# Altium schematic sheet toplevel.SchDoc(toplevel)
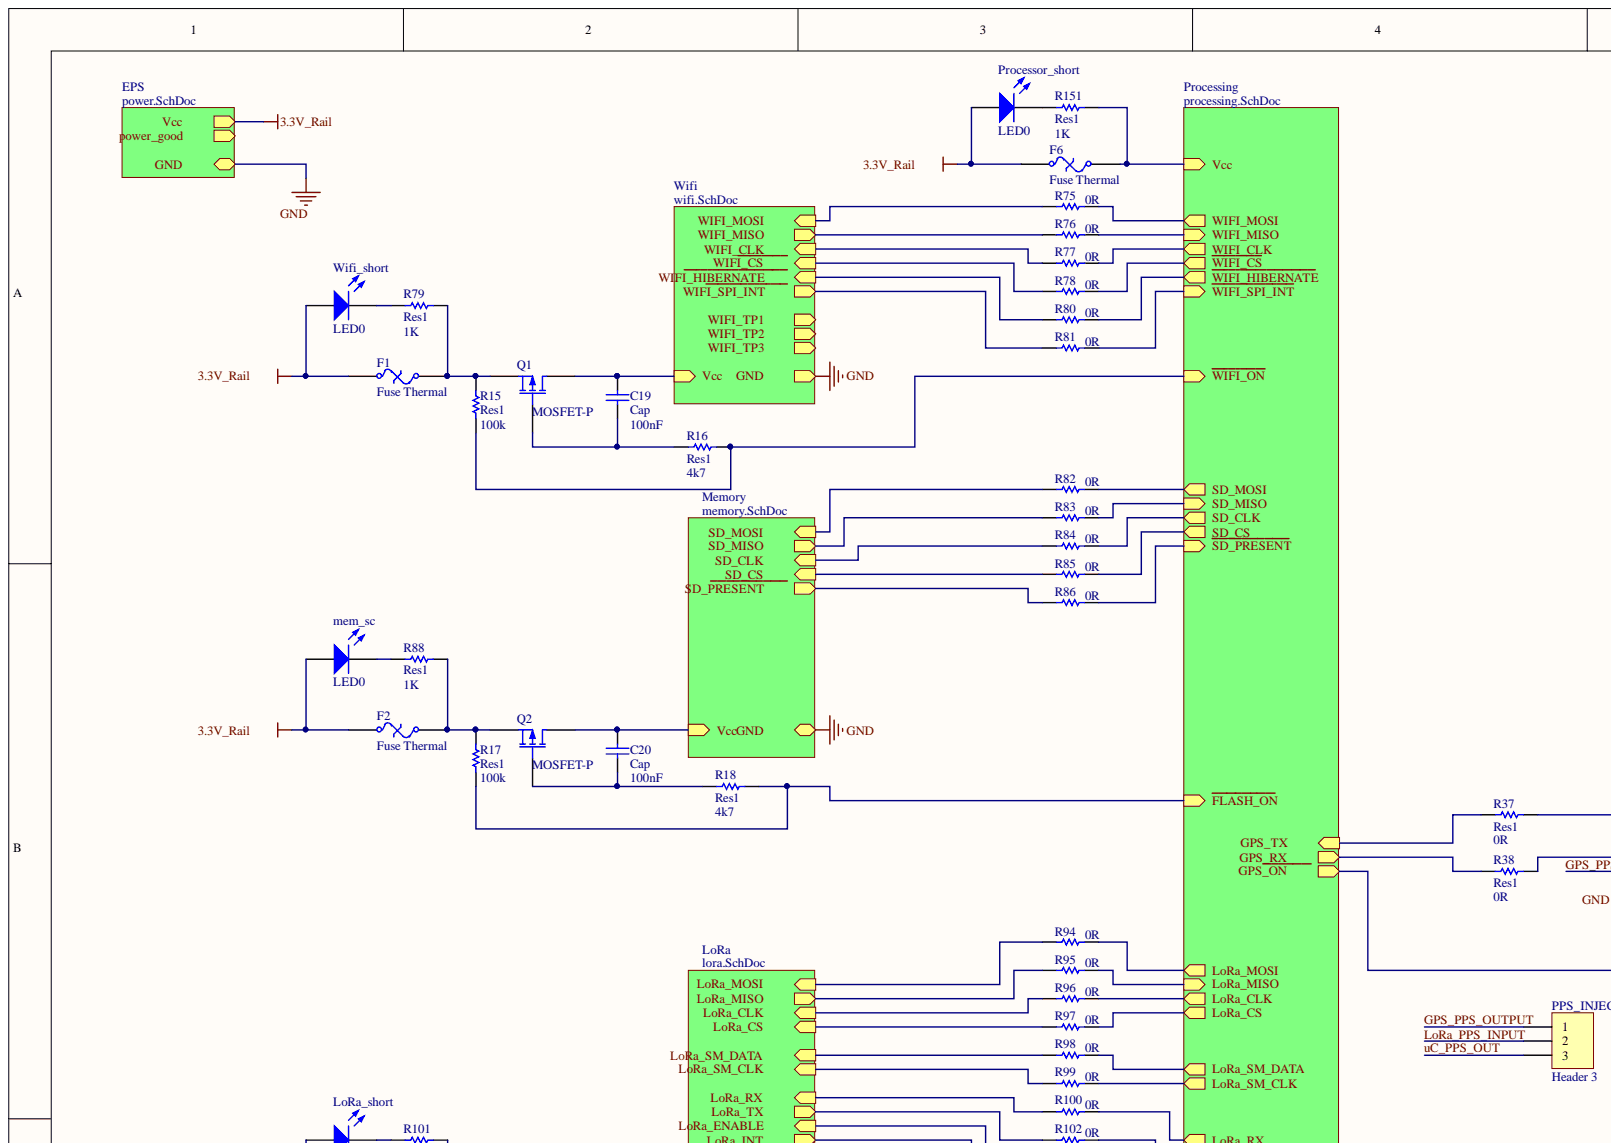
[diagram p0: toplevel.SchDoc, top left]
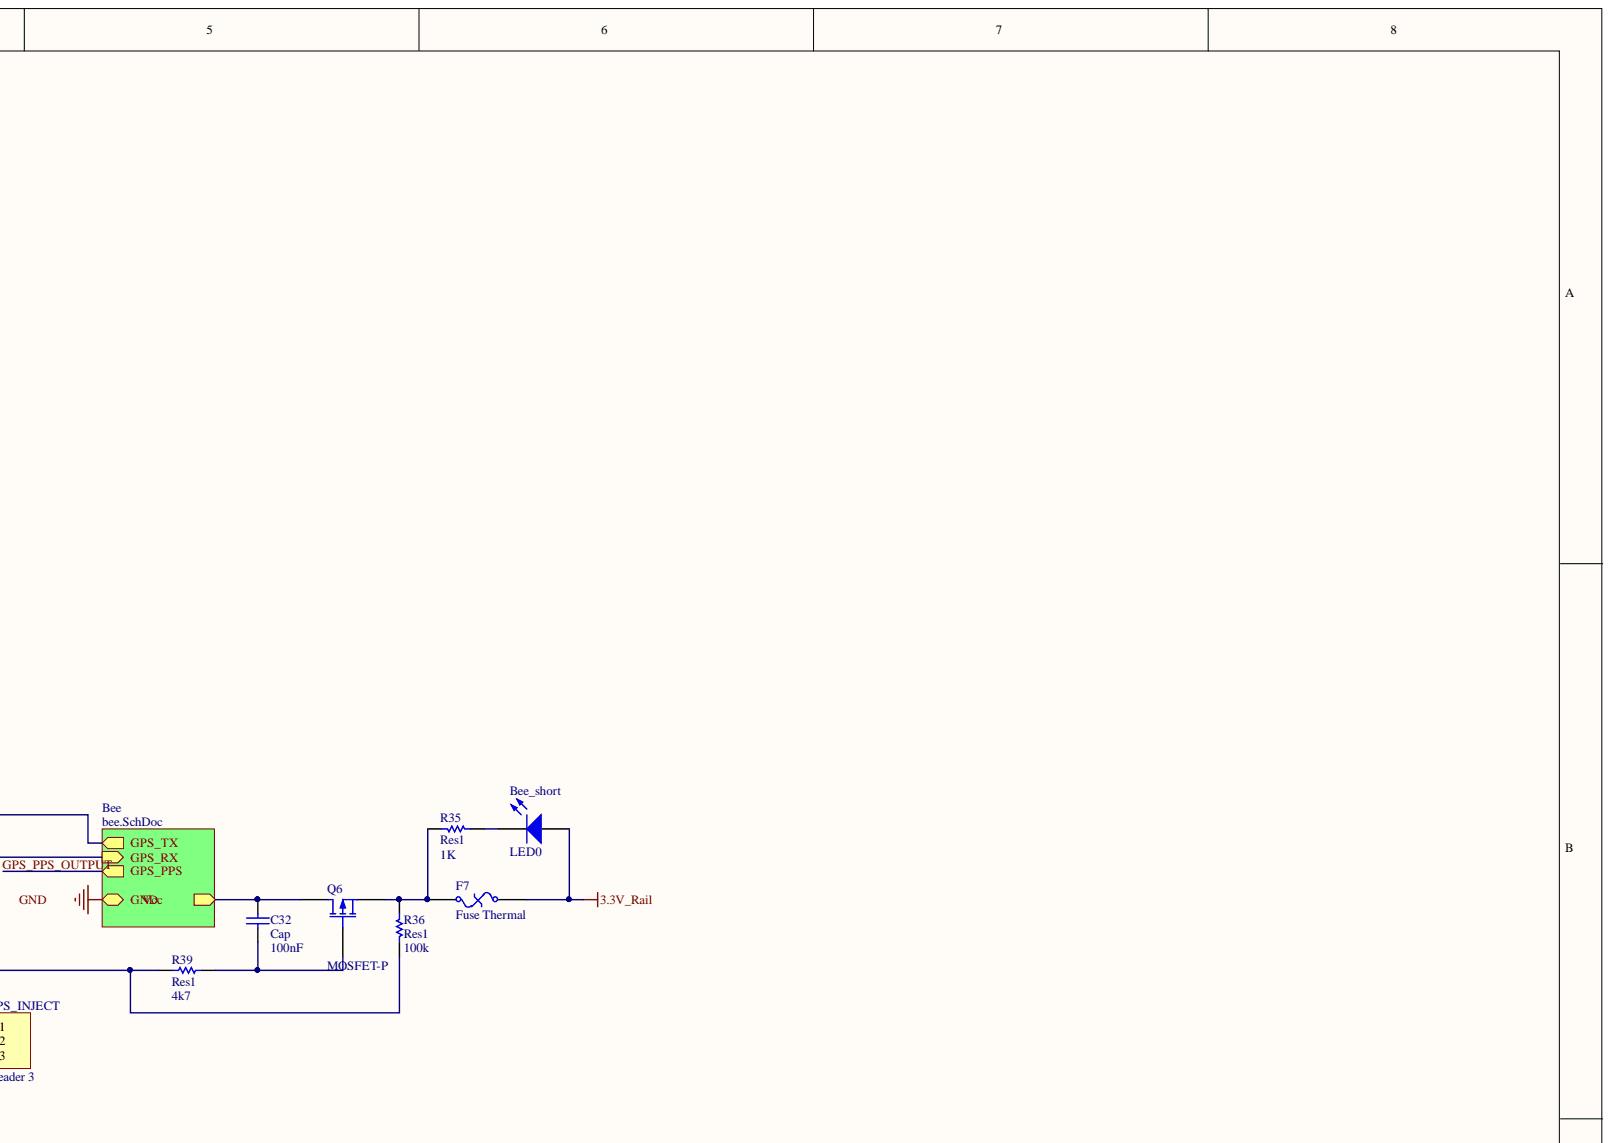
[diagram p1: toplevel.SchDoc, top right]
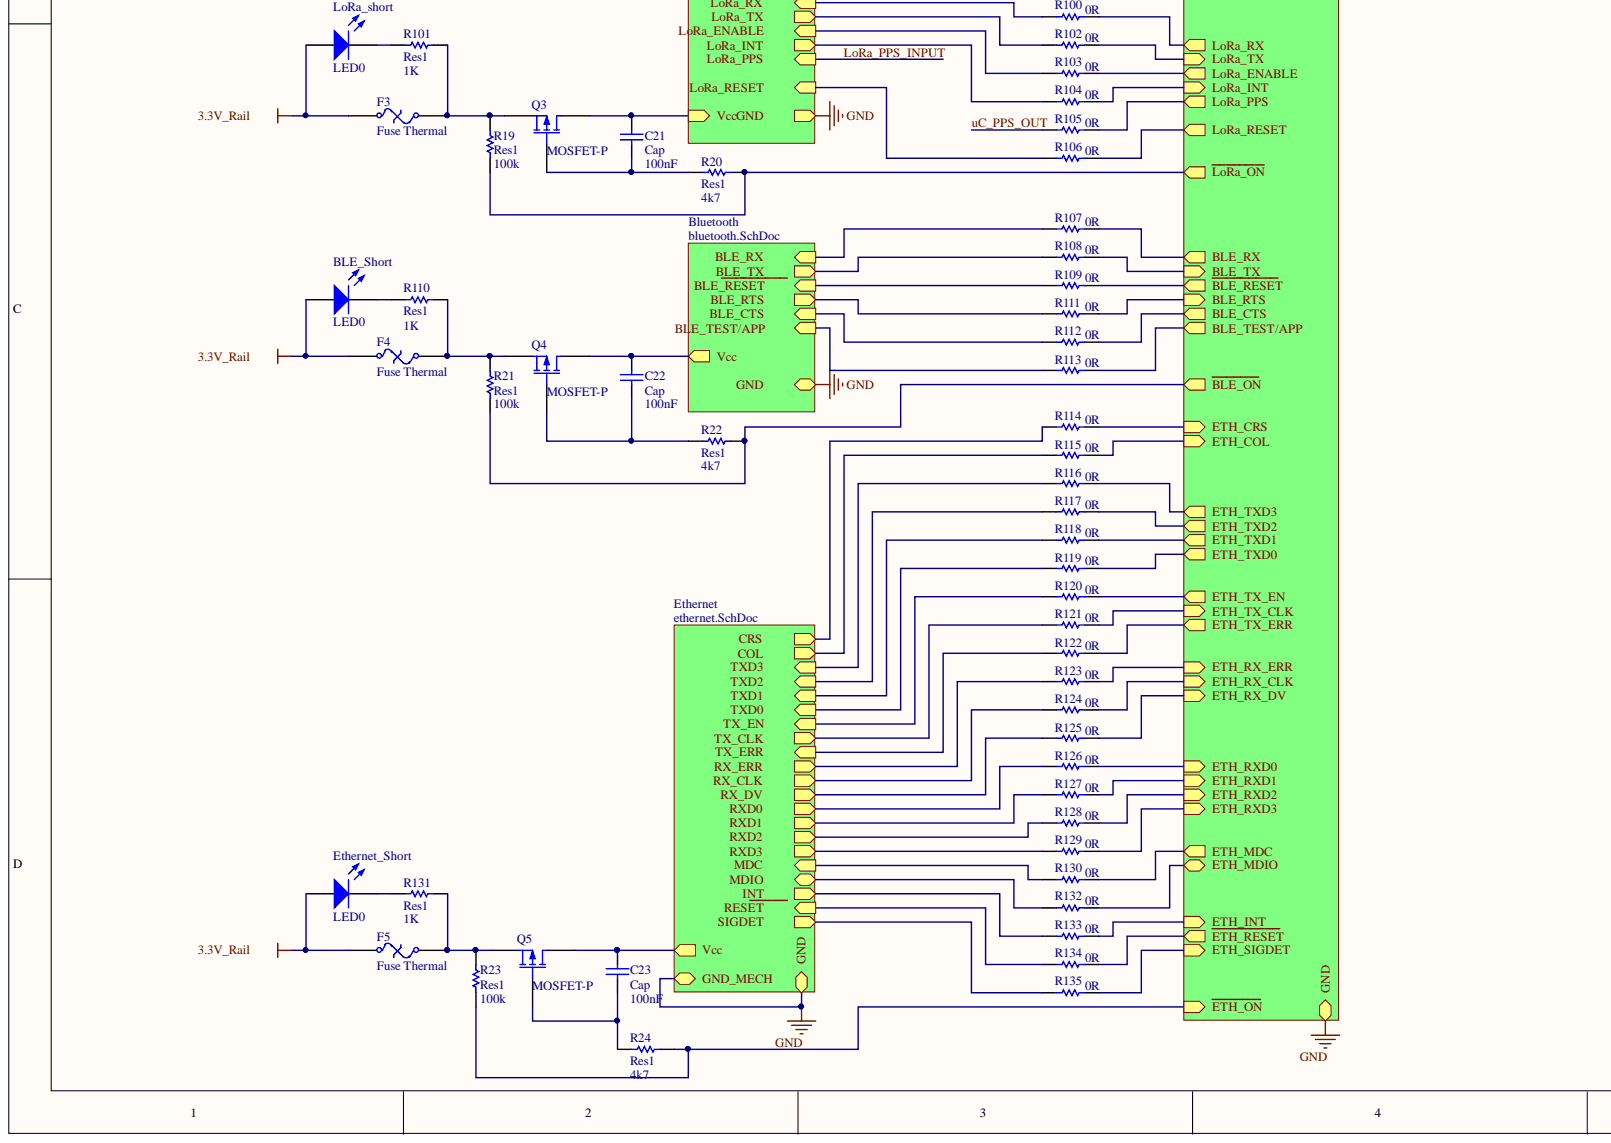
[diagram p2: toplevel.SchDoc, bottom left]
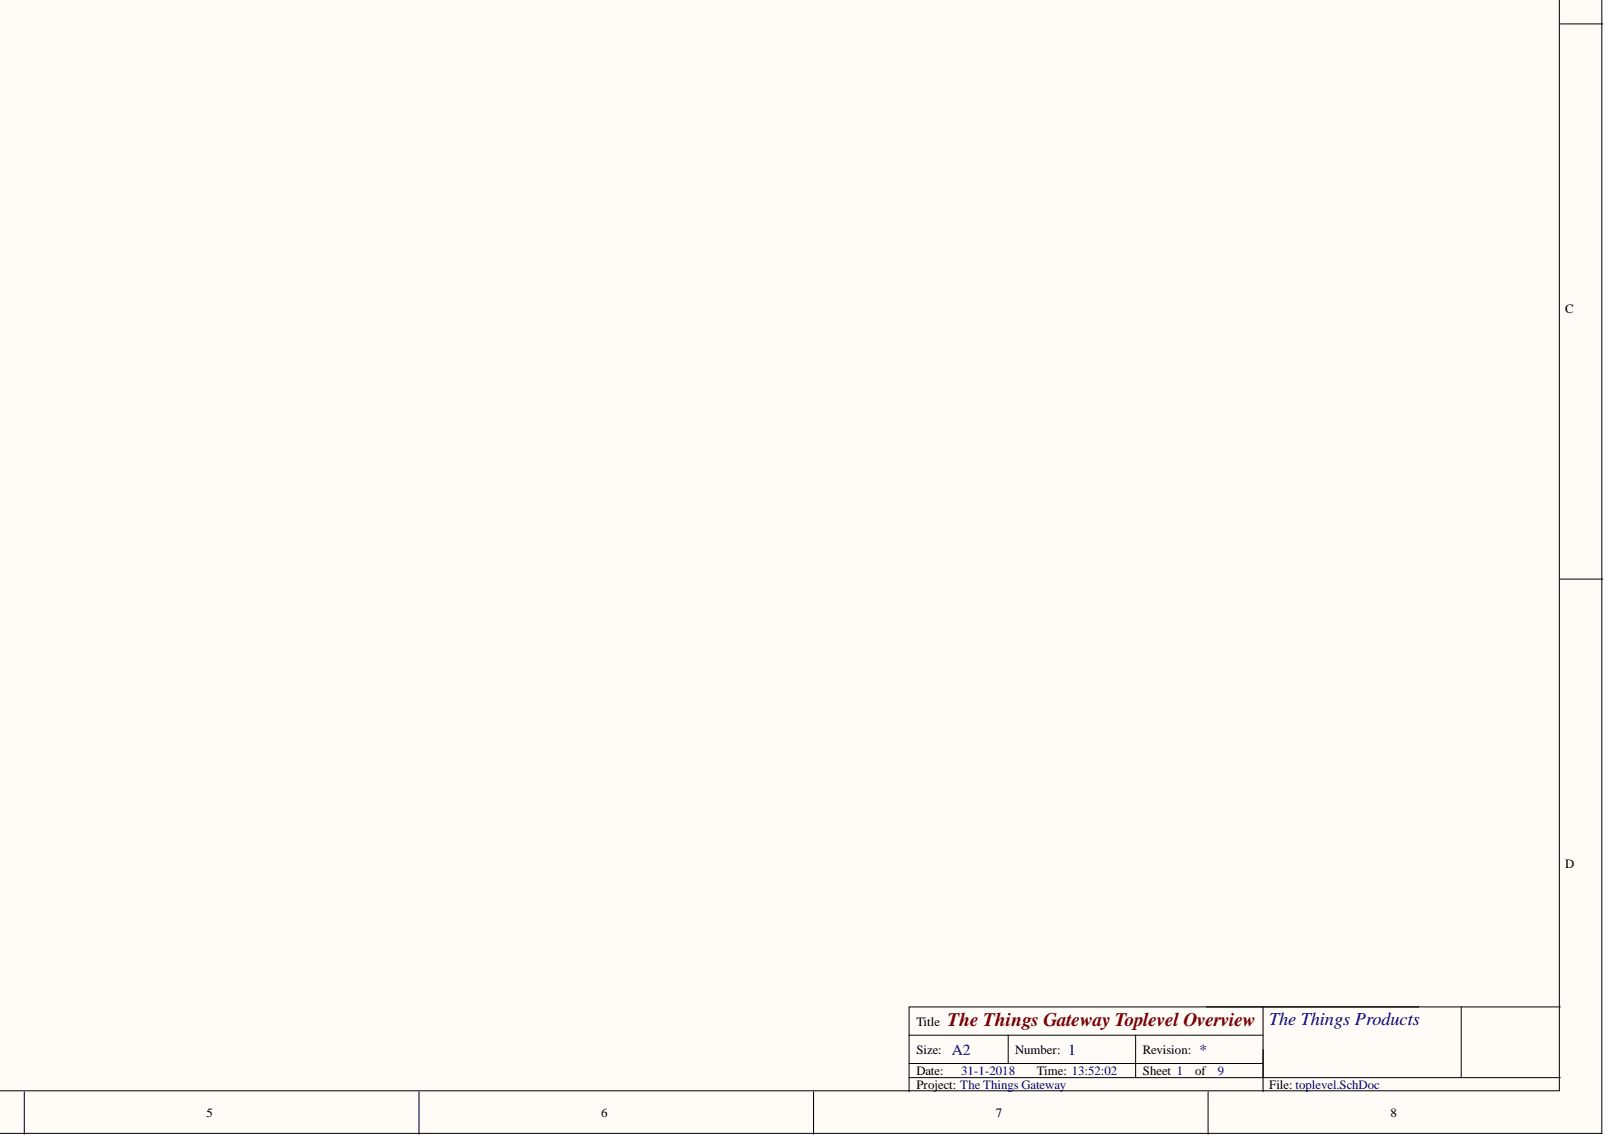
[diagram p3: toplevel.SchDoc, bottom right]

## Parts
Bee_short  LED0  [C0603]
BLE_Short  LED0  [C0603]
C19  Cap  value 100nF  [6-0805_L]
C20  Cap  value 100nF  [6-0805_L]
C21  Cap  value 100nF  [6-0805_L]
C22  Cap  value 100nF  [6-0805_L]
C23  Cap  value 100nF  [6-0805_L]
C32  Cap  value 100nF  [6-0805_L]
Ethernet_Short  LED0  [C0603]
F1  Fuse Thermal  [1812-polyfuse]
F2  Fuse Thermal  [1812-polyfuse]
F3  Fuse Thermal  [1812-polyfuse]
F4  Fuse Thermal  [1812-polyfuse]
F5  Fuse Thermal  [1812-polyfuse]
F6  Fuse Thermal  [1812-polyfuse]
F7  Fuse Thermal  [1812-polyfuse]
LoRa_short  LED0  [C0603]
mem_sc  LED0  [C0603]
PPS_INJECT  Header 3  [HDR1X3]
Processor_short  LED0  [C0603]
Q1  MOSFET-P  [SOT23_N]
Q2  MOSFET-P  [SOT23_N]
Q3  MOSFET-P  [SOT23_N]
Q4  MOSFET-P  [SOT23_N]
Q5  MOSFET-P  [SOT23_N]
Q6  MOSFET-P  [SOT23_N]
R15  Res1  value 100k  [R0603]
R16  Res1  value 4k7  [R0603]
R17  Res1  value 100k  [R0603]
R18  Res1  value 4k7  [R0603]
R19  Res1  value 100k  [R0603]
R20  Res1  value 4k7  [R0603]
R21  Res1  value 100k  [R0603]
R22  Res1  value 4k7  [R0603]
R23  Res1  value 100k  [R0603]
R24  Res1  value 4k7  [R0603]
R35  Res1  value 1K  [R0603]
R36  Res1  value 100k  [R0603]
R37  Res1  value 0R  [R0603]
R38  Res1  value 0R  [R0603]
R39  Res1  value 4k7  [R0603]
R75  value 0R  [R0603]
R76  value 0R  [R0603]
R77  value 0R  [R0603]
R78  value 0R  [R0603]
R79  Res1  value 1K  [R0603]
R80  value 0R  [R0603]
R81  value 0R  [R0603]
R82  value 0R  [R0603]
R83  value 0R  [R0603]
R84  value 0R  [R0603]
R85  value 0R  [R0603]
R86  value 0R  [R0603]
R88  Res1  value 1K  [R0603]
R94  value 0R  [R0603]
R95  value 0R  [R0603]
R96  value 0R  [R0603]
R97  value 0R  [R0603]
R98  value 0R  [R0603]
R99  value 0R  [R0603]
R100  value 0R  [R0603]
R101  Res1  value 1K  [R0603]
R102  value 0R  [R0603]
R103  value 0R  [R0603]
R104  value 0R  [R0603]
R105  value 0R  [R0603]
R106  value 0R  [R0603]
R107  value 0R  [R0603]
R108  value 0R  [R0603]
R109  value 0R  [R0603]
R110  Res1  value 1K  [R0603]
R111  value 0R  [R0603]
R112  value 0R  [R0603]
R113  value 0R  [R0603]
R114  value 0R  [R0603]
R115  value 0R  [R0603]
R116  value 0R  [R0603]
R117  value 0R  [R0603]
R118  value 0R  [R0603]
R119  value 0R  [R0603]
R120  value 0R  [R0603]
R121  value 0R  [R0603]
R122  value 0R  [R0603]
R123  value 0R  [R0603]
R124  value 0R  [R0603]
R125  value 0R  [R0603]
R126  value 0R  [R0603]
R127  value 0R  [R0603]
R128  value 0R  [R0603]
R129  value 0R  [R0603]
R130  value 0R  [R0603]
R131  Res1  value 1K  [R0603]
R132  value 0R  [R0603]
R133  value 0R  [R0603]
R134  value 0R  [R0603]
R135  value 0R  [R0603]
R151  Res1  value 1K  [R0603]
Wifi_short  LED0  [C0603]

## Nets
3.3V_RAIL: Bee_short.1 BLE_Short.1 Ethernet_Short.1 F1.1 F2.1 F3.1 F4.1 F5.1 F6.1 F7.1 LoRa_short.1 mem_sc.1 Processor_short.1 Wifi_short.1
GPS_PPS_OUTPUT: PPS_INJECT.1
LORA_PPS_INPUT: PPS_INJECT.2
UC_PPS_OUT: PPS_INJECT.3 R105.1
NetBee_short_2: Bee_short.2 R35.1
NetBLE_Short_2: BLE_Short.2 R110.1
NetC19_1: C19.1 Q1.2 R16.1
NetC19_2: C19.2 Q1.1
NetC20_1: C20.1 Q2.2 R18.1
NetC20_2: C20.2 Q2.1
NetC21_1: C21.1 Q3.2 R20.1
NetC21_2: C21.2 Q3.1
NetC22_1: C22.1 Q4.2 R22.1
NetC22_2: C22.2 Q4.1
NetC23_1: C23.1 Q5.2 R24.1
NetC23_2: C23.2 Q5.1
NetC32_1: C32.1 Q6.2 R39.2
NetC32_2: C32.2 Q6.1
NetEthernet_Short_2: Ethernet_Short.2 R131.1
NetF1_2: F1.2 Q1.3 R15.2 R79.2
NetF2_2: F2.2 Q2.3 R17.2 R88.2
NetF3_2: F3.2 Q3.3 R19.2 R101.2
NetF4_2: F4.2 Q4.3 R21.2 R110.2
NetF5_2: F5.2 Q5.3 R23.2 R131.2
NetF6_2: F6.2 R151.2
NetF7_2: F7.2 Q6.3 R35.2 R36.2
NetLoRa_short_2: LoRa_short.2 R101.1
Netmem_sc_2: mem_sc.2 R88.1
NetProcessor_short_2: Processor_short.2 R151.1
NetR15_1: R15.1 R16.2
NetR17_1: R17.1 R18.2
NetR19_1: R19.1 R20.2
NetR21_1: R21.1 R22.2
NetR23_1: R23.1 R24.2
NetR36_1: R36.1 R39.1
NetR37_1: R37.1
NetR37_2: R37.2
NetR38_1: R38.1
NetR38_2: R38.2
NetR75_1: R75.1
NetR75_2: R75.2
NetR76_1: R76.1
NetR76_2: R76.2
NetR77_1: R77.1
NetR77_2: R77.2
NetR78_1: R78.1
NetR78_2: R78.2
NetR79_1: R79.1 Wifi_short.2
NetR80_1: R80.1
NetR80_2: R80.2
NetR81_1: R81.1
NetR81_2: R81.2
NetR82_1: R82.1
NetR82_2: R82.2
NetR83_1: R83.1
NetR83_2: R83.2
NetR84_1: R84.1
NetR84_2: R84.2
NetR85_1: R85.1
NetR85_2: R85.2
NetR86_1: R86.1
NetR86_2: R86.2
NetR94_1: R94.1
NetR94_2: R94.2
NetR95_1: R95.1
NetR95_2: R95.2
NetR96_1: R96.1
NetR96_2: R96.2
NetR97_1: R97.1
NetR97_2: R97.2
NetR98_1: R98.1
NetR98_2: R98.2
NetR99_1: R99.1
NetR99_2: R99.2
NetR100_1: R100.1
NetR100_2: R100.2
NetR102_1: R102.1
NetR102_2: R102.2
NetR103_1: R103.1
NetR103_2: R103.2
NetR104_1: R104.1
NetR104_2: R104.2
NetR105_2: R105.2
NetR106_1: R106.1
NetR106_2: R106.2
NetR107_1: R107.1
NetR107_2: R107.2
NetR108_1: R108.1
NetR108_2: R108.2
NetR109_1: R109.1
NetR109_2: R109.2
NetR111_1: R111.1
NetR111_2: R111.2
NetR112_1: R112.1
NetR112_2: R112.2
NetR113_1: R113.1
NetR113_2: R113.2
NetR114_1: R114.1
NetR114_2: R114.2
NetR115_1: R115.1
NetR115_2: R115.2
NetR116_1: R116.1
NetR116_2: R116.2
NetR117_1: R117.1
NetR117_2: R117.2
NetR118_1: R118.1
NetR118_2: R118.2
NetR119_1: R119.1
NetR119_2: R119.2
NetR120_1: R120.1
NetR120_2: R120.2
NetR121_1: R121.1
NetR121_2: R121.2
NetR122_1: R122.1
NetR122_2: R122.2
NetR123_1: R123.1
NetR123_2: R123.2
NetR124_1: R124.1
NetR124_2: R124.2
NetR125_1: R125.1
NetR125_2: R125.2
NetR126_1: R126.1
NetR126_2: R126.2
NetR127_1: R127.1
NetR127_2: R127.2
NetR128_1: R128.1
NetR128_2: R128.2
NetR129_1: R129.1
NetR129_2: R129.2
NetR130_1: R130.1
NetR130_2: R130.2
NetR132_1: R132.1
NetR132_2: R132.2
NetR133_1: R133.1
NetR133_2: R133.2
NetR134_1: R134.1
NetR134_2: R134.2
NetR135_1: R135.1
NetR135_2: R135.2
GND:
POWER_GOOD:
WIFI_TP1:
WIFI_TP2:
WIFI_TP3:

## Library data of the parts
Bee_short BLE_Short Ethernet_Short LoRa_short mem_sc Processor_short Wifi_short = LED0: Typical INFRARED GaAs LED; Component Kind: Standard; LatestRevisionDate: 08-Jul-2005; LatestRevisionNote: Mech Layer 1 removed.; PackageDescription: LED; 2 Leads; PackageReference: LED-0; Published: 8-Jun-2000; Simulation: LED0; Library Name: any; Publisher: Altium Limited
C19-C23 C32 = Cap: Capacitor; Component Kind: Standard; LatestRevisionDate: 17-Jul-2002; LatestRevisionNote: Re-released for DXP Platform.; Library Name: Miscellaneous Devices.IntLib; PackageDescription: Radial Cap, Thru-Hole; 2 Leads; 0.3 in Pin Spacing; PackageReference: RAD-0.3; Published: 8-Jun-2000; Signal Integrity: Cap; Simulation: CAP; Publisher: Altium Limited
F1-F7 = Fuse Thermal: Thermal Fuse; Component Kind: Standard; LatestRevisionDate: 17-Jul-2002; LatestRevisionNote: Re-released for DXP Platform.; PackageDescription: Thru-Hole; 2 Leads; PackageReference: PIN-W2/E2.8; Published: 24-Mar-1999; Library Name: Any; Publisher: Altium Limited
PPS_INJECT = Header 3: Header, 3-Pin; Component Kind: Standard; LatestRevisionDate: 17-Jul-2002; LatestRevisionNote: Re-released for DXP Platform.; Library Name: Miscellaneous Connectors.IntLib; Signal Integrity: Connector; Publisher: Altium Limited
Q1-Q6 = MOSFET-P: P-Channel MOSFET; Component Kind: Standard; LatestRevisionDate: 17-Jul-2002; LatestRevisionNote: Re-released for DXP Platform.; Library Name: Miscellaneous Devices.IntLib; PackageDescription: TO, Flat Index; 3 In-Line, Axial Leads; Body Dia. 4.6mm; Lead Dia. 0.5 mm (max); PackageReference: E3; PackageVersion: 1995/1996; Published: 8-Jun-2000; Simulation: PMOS; Publisher: Altium Limited
R15-R24 R35 R36 R38 R39 R75-R86 R88 R94-R135 = Res1: Resistor; Component Kind: Standard; LatestRevisionDate: 17-Jul-2002; LatestRevisionNote: Re-released for DXP Platform.; PackageDescription: Axial Device, Thru-Hole; 2 Leads; 0.3 in Pin Spacing; PackageReference: AXIAL-0.3; Published: 8-Jun-2000; Signal Integrity: Res; Simulation: RESISTOR; Publisher: Altium Limited
  R15-R24 R36 R38 R39: Library Name: Miscellaneous Devices.IntLib
  R35 R75-R86 R88 R94-R135: Library Name: any
R37 = Res1: Resistor; Value: 0R; Component Kind: Standard; LatestRevisionDate: 17-Jul-2002; LatestRevisionNote: Re-released for DXP Platform.; Library Name: Miscellaneous Devices.IntLib; PackageDescription: Axial Device, Thru-Hole; 2 Leads; 0.3 in Pin Spacing; PackageReference: AXIAL-0.3; Published: 8-Jun-2000; Signal Integrity: Res; Simulation: RESISTOR; Publisher: Altium Limited
R151 = Res1: Resistor; Value: 1K; Component Kind: Standard; LatestRevisionDate: 17-Jul-2002; LatestRevisionNote: Re-released for DXP Platform.; PackageDescription: Axial Device, Thru-Hole; 2 Leads; 0.3 in Pin Spacing; PackageReference: AXIAL-0.3; Published: 8-Jun-2000; Signal Integrity: Res; Simulation: RESISTOR; Library Name: any; Publisher: Altium Limited
